# Altium schematic sheet FPGA_MIO.SchDoc(FPGA_MIO)
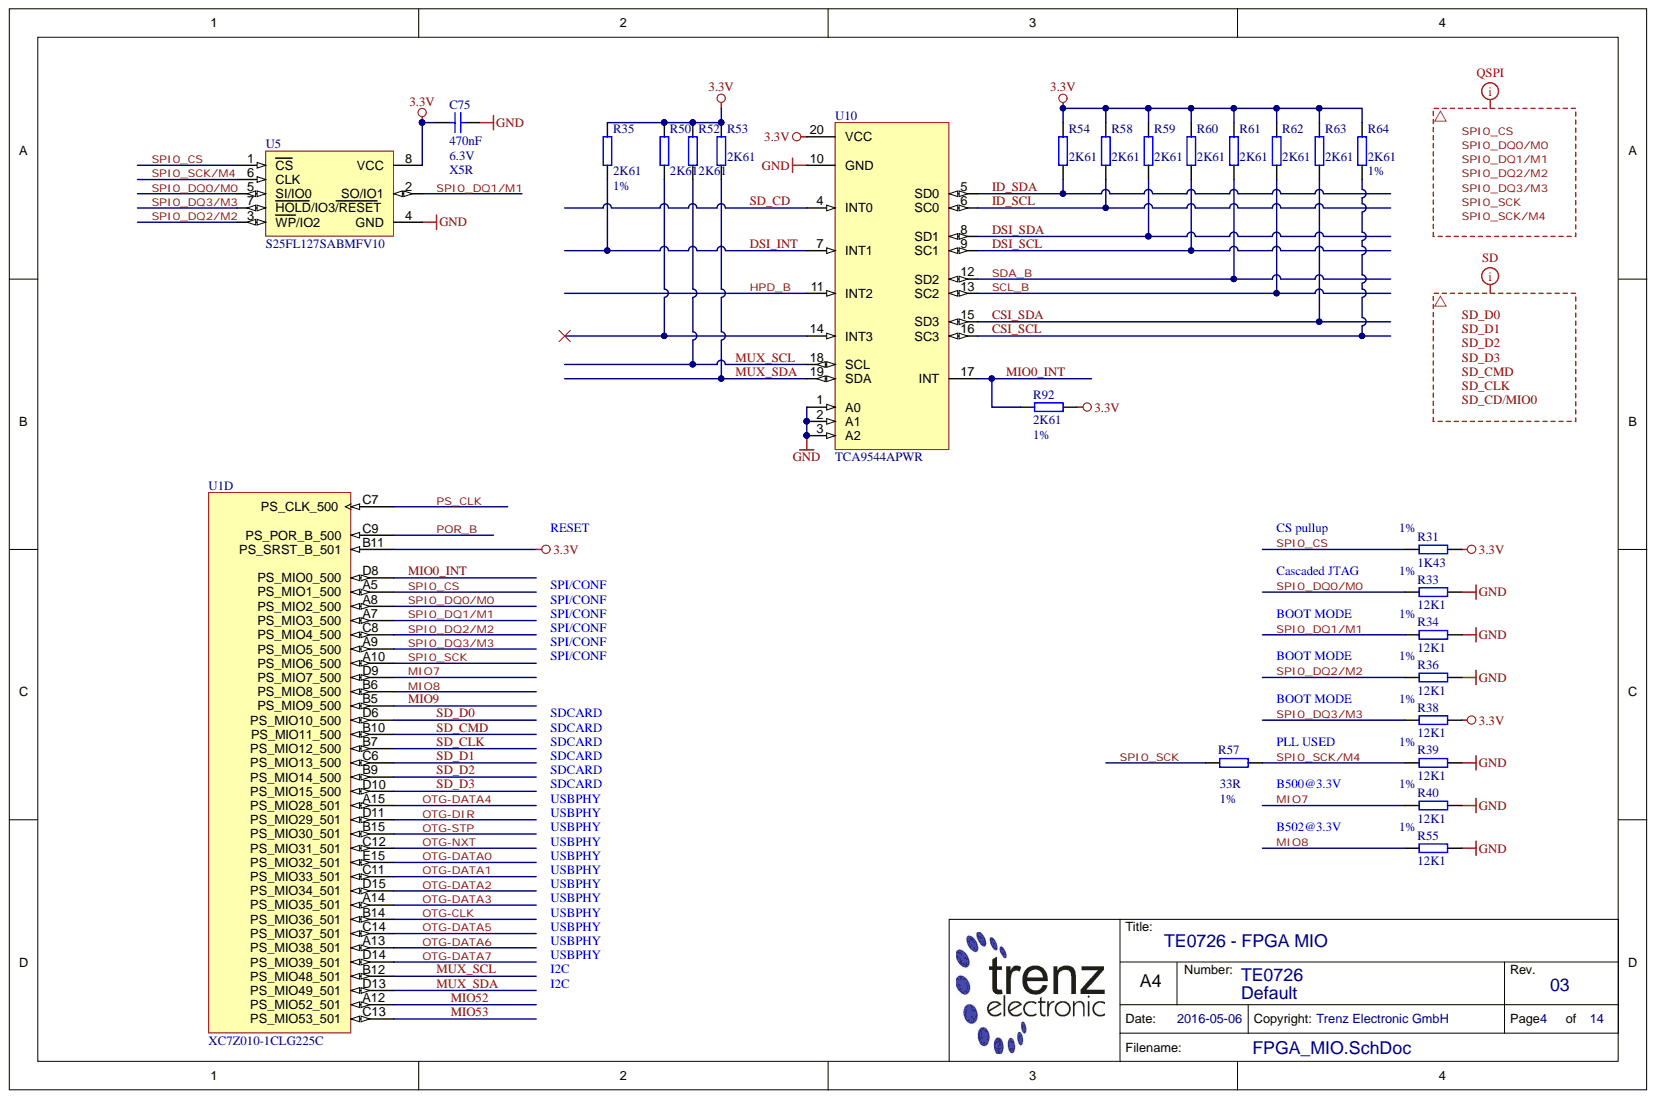
[diagram p0: FPGA_MIO.SchDoc]

## Parts
C75  470nF  Voltage: 6.3V  [CAPC0603X33N]
R31  1K43  Tolerance: 1%  [RESC1005X40N]
R33  12K1  Tolerance: 1%  [RESC1005X40N]
R34  12K1  Tolerance: 1%  [RESC1005X40N]
R35  2K61  Tolerance: 1%  [RESC1005X40N]
R36  12K1  Tolerance: 1%  [RESC1005X40N]
R38  12K1  Tolerance: 1%  [RESC1005X40N]
R39  12K1  Tolerance: 1%  [RESC1005X40N]
R40  12K1  Tolerance: 1%  [RESC1005X40N]
R50  2K61  [RESC1005X40N]
R52  2K61  [RESC1005X40N]
R53  2K61  [RESC1005X40N]
R54  2K61  [RESC1005X40N]
R55  12K1  Tolerance: 1%  [RESC1005X40N]
R57  33R  [RESC0603X26N]
R58  2K61  [RESC1005X40N]
R59  2K61  [RESC1005X40N]
R60  2K61  [RESC1005X40N]
R61  2K61  [RESC1005X40N]
R62  2K61  [RESC1005X40N]
R63  2K61  [RESC1005X40N]
R64  2K61  Tolerance: 1%  [RESC1005X40N]
R92  2K61  Tolerance: 1%  [RESC1005X40N]
U1  XC7Z010-1CLG225C  [BGA225C80P15X15_1300X1300X150B40N]  (part D)
U5  S25FL127SABMFV10  [SOIC127P790X216-8N]
U10  TCA9544APWR  [SOP65P640X120-20N]

## Nets
3.3V: C75.1 R31.2 R35.2 R38.2 R50.2 R52.2 R53.2 R54.2 R58.2 R59.2 R60.2 R61.2 R62.2 R63.2 R64.2 R92.1 U1.B11(PS_SRST_B_501) U5.8(VCC) U10.20(VCC)
GND: C75.2 R33.2 R34.2 R36.2 R39.2 R40.2 R55.2 U5.4(GND) U10.1(A0) U10.2(A1) U10.3(A2) U10.10(GND)
CSI_SCL: R64.1 U10.16(SC3)
CSI_SDA: R63.1 U10.15(SD3)
DSI_INT: R35.1 U10.7(INT1)
DSI_SCL: R60.1 U10.9(SC1)
DSI_SDA: R59.1 U10.8(SD1)
HPD_B: U10.11(INT2)
ID_SCL: R58.1 U10.6(SC0)
ID_SDA: R54.1 U10.5(SD0)
MIO0_INT: R92.2 U1.D8(PS_MIO0_500) U10.17(INT)
MIO7: R40.1 U1.D9(PS_MIO7_500)
MIO8: R55.1 U1.B6(PS_MIO8_500)
MIO9: U1.B5(PS_MIO9_500)
MIO52: U1.A12(PS_MIO52_501)
MIO53: U1.C13(PS_MIO53_501)
MUX_SCL: R52.1 U1.B12(PS_MIO48_501) U10.18(SCL)
MUX_SDA: R53.1 U1.D13(PS_MIO49_501) U10.19(SDA)
OTG-CLK: U1.B14(PS_MIO36_501)
OTG-DATA0: U1.E15(PS_MIO32_501)
OTG-DATA1: U1.C11(PS_MIO33_501)
OTG-DATA2: U1.D15(PS_MIO34_501)
OTG-DATA3: U1.A14(PS_MIO35_501)
OTG-DATA4: U1.A15(PS_MIO28_501)
OTG-DATA5: U1.C14(PS_MIO37_501)
OTG-DATA6: U1.A13(PS_MIO38_501)
OTG-DATA7: U1.D14(PS_MIO39_501)
OTG-DIR: U1.D11(PS_MIO29_501)
OTG-NXT: U1.C12(PS_MIO31_501)
OTG-STP: U1.B15(PS_MIO30_501)
POR_B: U1.C9(PS_POR_B_500)
PS_CLK: U1.C7(PS_CLK_500)
SCL_B: R62.1 U10.13(SC2)
SD_CD: U10.4(INT0)
SD_CLK: U1.B7(PS_MIO12_500)
SD_CMD: U1.B10(PS_MIO11_500)
SD_D0: U1.D6(PS_MIO10_500)
SD_D1: U1.C6(PS_MIO13_500)
SD_D2: U1.B9(PS_MIO14_500)
SD_D3: U1.D10(PS_MIO15_500)
SDA_B: R61.1 U10.12(SD2)
SPI0_CS: R31.1 U1.A5(PS_MIO1_500) U5.1(CS)
SPI0_DQ0/M0: R33.1 U1.A8(PS_MIO2_500) U5.5(SI/IO0)
SPI0_DQ1/M1: R34.1 U1.A7(PS_MIO3_500) U5.2(SO/IO1)
SPI0_DQ2/M2: R36.1 U1.C8(PS_MIO4_500) U5.3(WP/IO2)
SPI0_DQ3/M3: R38.1 U1.A9(PS_MIO5_500) U5.7(HOLD/IO3/RESET)
SPI0_SCK: R57.1 U1.A10(PS_MIO6_500)
SPI0_SCK/M4: R39.1 R57.2 U5.6(CLK)
NetR50_1: R50.1 U10.14(INT3)
N00166:
N00167:
SD_CD/MIO0:

## Library data of the parts
C75 = 24921: KON 470nF X5R 6.3V SMD 20%; Database Table Name: C_0603_0201; Mixed: SMT; Preferred: Yes; Value: 470n; AN: 24921; Case: 0603 (0201); Component Kind: Standard; Library Name: TE.DbLib; Technology: X5R; Tolerance: 20%
R31 = 23473: WID SMD 1K43 1% 0,063W; Database Table Name: R_1005_0402; Mixed: SMT; Value: 1.43K; AN: 23473; Case: 1005 (0402); Component Kind: Standard; Library Name: TE.DbLib; Technology: TK100
R33 R34 R36 R38-R40 R55 = 22849: WID SMD 12K1 1% 0,063W; Database Table Name: R_1005_0402; Mixed: SMT; Preferred: Yes; Value: 12.1K; AN: 22849; Case: 1005 (0402); Component Kind: Standard; Library Name: TE.DbLib; Technology: TK100
R35 R50 R52-R54 R58-R64 R92 = 23730: WID SMD 2K61 1% 0,063W; Database Table Name: R_1005_0402; Mixed: SMT; Preferred: Yes; Value: 2.61K; AN: 23730; Case: 1005 (0402); Component Kind: Standard; Library Name: TE.DbLib; Technology: TK100
  R50 R52-R54 R58-R63: Tolerance: 1%
R57 = 25021: WID SMD 33R 1% 0,05W; Database Table Name: R_0603_0201; Mixed: SMT; Preferred: Yes; Value: 33; AN: 25021; Case: 0603 (0201); Component Kind: Standard; Library Name: TE.DbLib; Technology: TK100; Tolerance: 1%; HelpURL: B:\AD\adlib\datasheet\R0201
U1 = 26308: Database Table Name: PROG_LOGIC; Mixed: SMT; Preferred: Yes; AN: 26308; Case: CLG225; Component Kind: Standard; Description: XC7Z010-1CLG225C; H-ART: XC7Z010-1CLG225C; Library Name: TE.DbLib; Trenz-Stock: Yes; HelpURL: B:\AD\adlib\datasheet\XC7\Zynq
U5 = 26078: S25FL127SABMFV10 automotive SO8; Database Table Name: A_FLASH; Mixed: SMT; Preferred: Yes; AN: 26078; Case: SO8W; Component Kind: Standard; H-ART: S25FL127SABMFV10; Library Name: TE.DbLib; HelpURL: B:\AD\adlib\datasheet\S25FL12xS
U10 = 27003: Database Table Name: IF; Mixed: SMT; Trenz-Stock: Yes; AN: 27003; Case: TSSOP20; Component Kind: Standard; Description: TCA9544APWR; H-ART: TCA9544APWR; Library Name: TE.DbLib; HelpURL: B:\AD\adlib\datasheet\TCA9544A
